# Altium schematic sheet [04] - MCU.SchDoc("[04] - MCU")
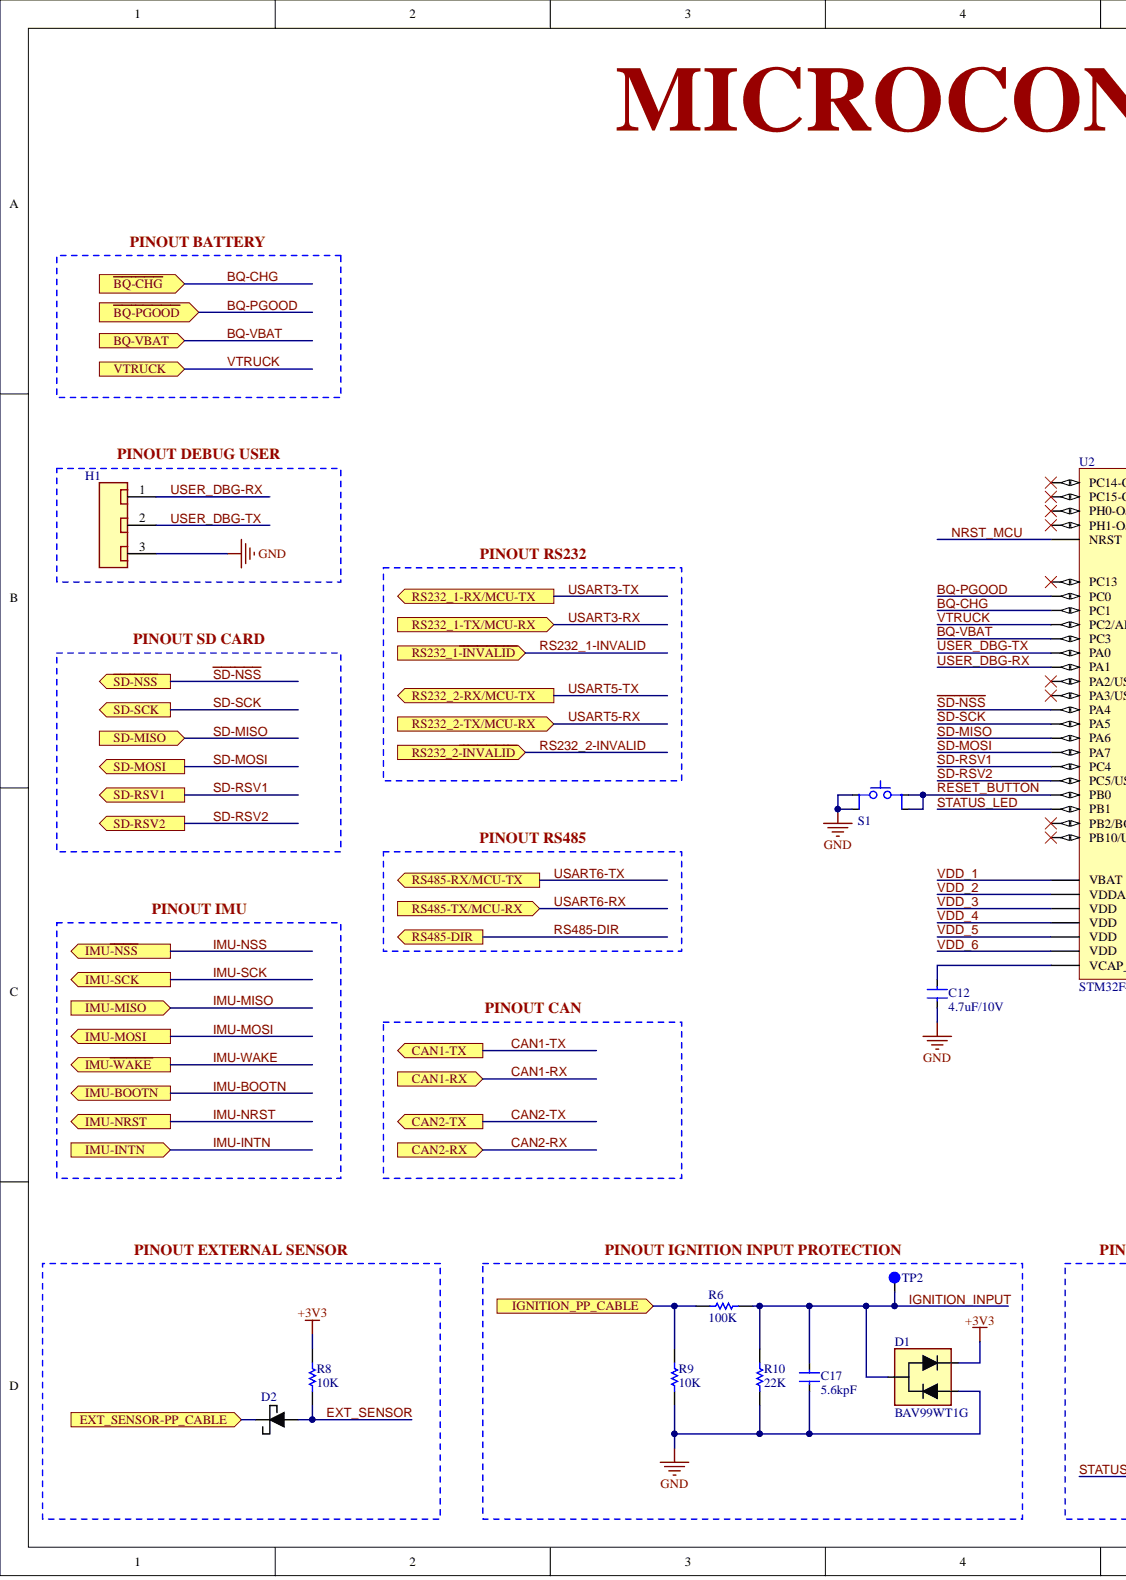
[diagram p0: [04] - MCU.SchDoc, left]
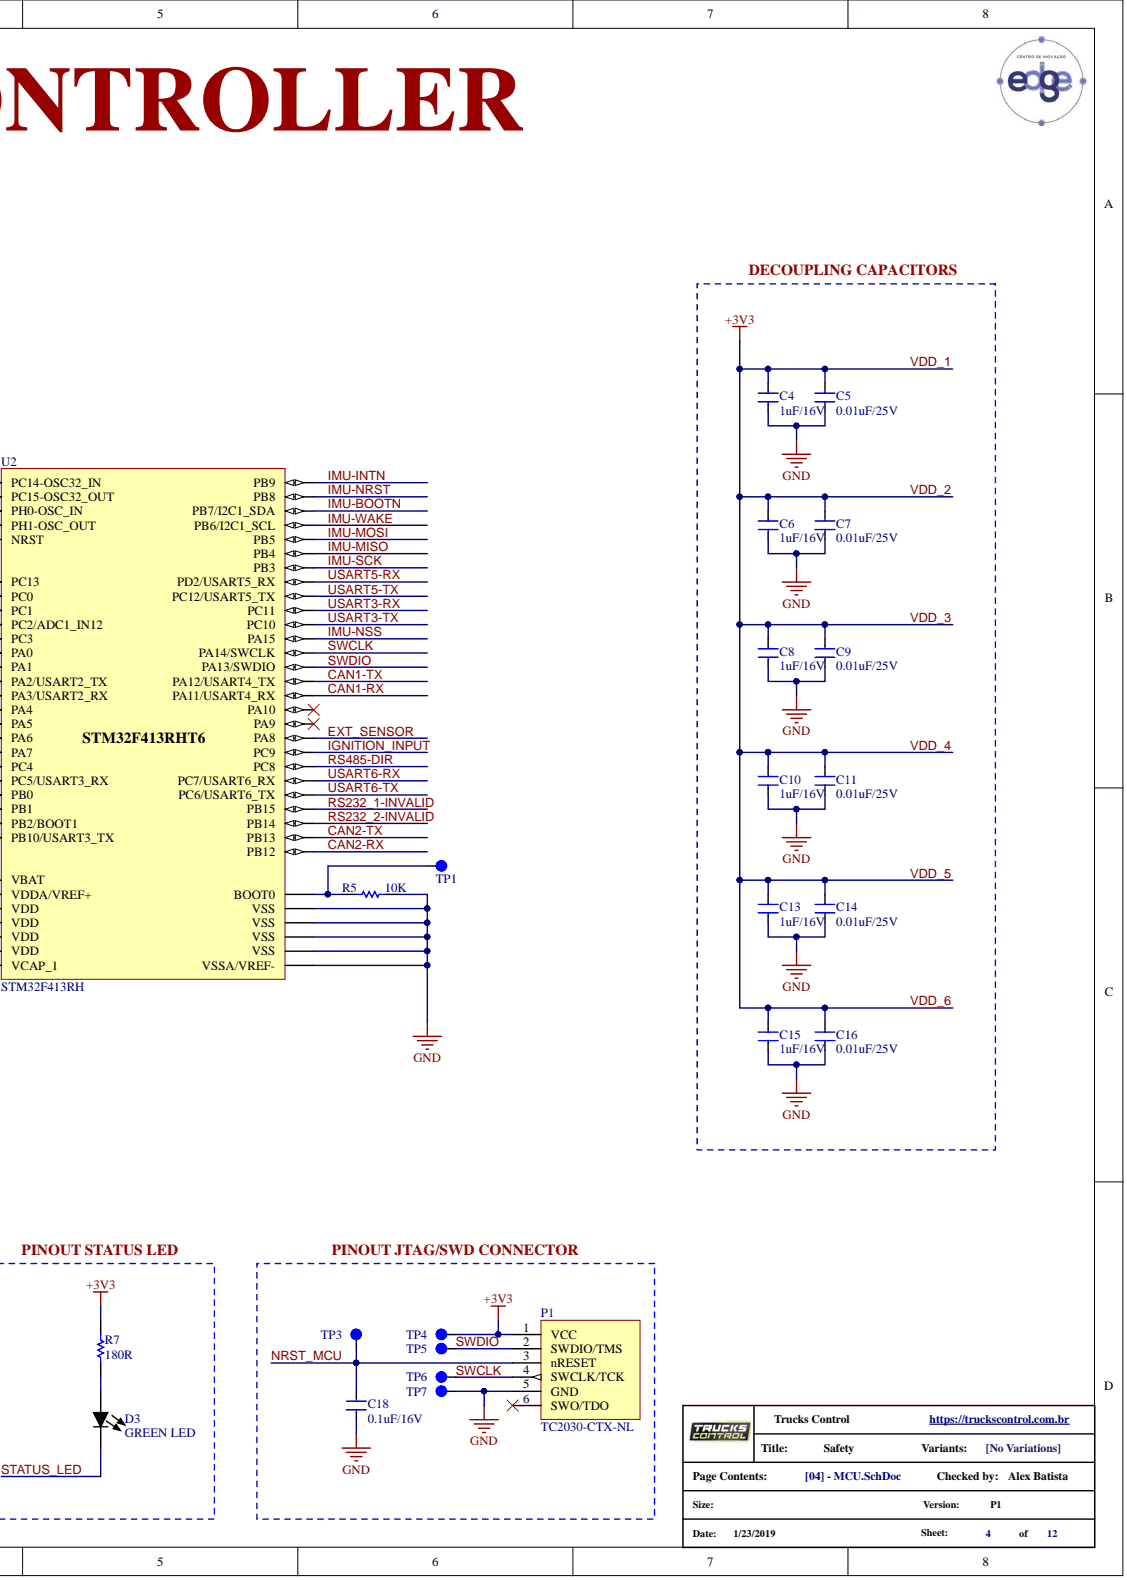
[diagram p1: [04] - MCU.SchDoc, right]

## Parts
C4  1uF/16V  [C0402]
C5  0.01uF/25V  [C0402]
C6  1uF/16V  [C0402]
C7  0.01uF/25V  [C0402]
C8  1uF/16V  [C0402]
C9  0.01uF/25V  [C0402]
C10  1uF/16V  [C0402]
C11  0.01uF/25V  [C0402]
C12  4.7uF/10V  [C0402]
C13  1uF/16V  [C0402]
C14  0.01uF/25V  [C0402]
C15  1uF/16V  [C0402]
C16  0.01uF/25V  [C0402]
C17  5.6kpF  [C0805]
C18  0.1uF/16V  [C0402]
D1  BAV99WT1G  [SOT-323]
D2  CCS15S40,L3F  [CCS15S40,L3F]  (value not drawn)
D3  GREEN LED  [HSMG-C680]
H1  Pin Header 1x3  [HEADER_1x3]  (value not drawn)
P1  TC2030-CTX-NL  [TC2030-CTX-NL]  (no BOM)
R5  10K  [R0402]
R6  100K  [R0805]
R7  180R  [R0402]
R8  10K  [R0402]
R9  10K  [R0805]
R10  22K  [R0805]
S1  Switch Button  [TL1014BF160QG]  (value not drawn)
TP1  TestPoint  [Test Point]  (value not drawn)  (no BOM)
TP2  TestPoint  [Test Point]  (value not drawn)  (no BOM)
TP3  TestPoint  [Test Point]  (value not drawn)  (no BOM)
TP4  TestPoint  [Test Point]  (value not drawn)  (no BOM)
TP5  TestPoint  [Test Point]  (value not drawn)  (no BOM)
TP6  TestPoint  [Test Point]  (value not drawn)  (no BOM)
TP7  TestPoint  [Test Point]  (value not drawn)  (no BOM)
U2  STM32F413RH  [TSQFP50P1200X1200X160-64N]

## Ports
BQ-VBAT, CAN1-RX, CAN1-TX, CAN2-RX, CAN2-TX, EXT_SENSOR-PP_CABLE, IGNITION_PP_CABLE, IMU-BOOTN, IMU-INTN, IMU-MISO, IMU-MOSI, IMU-NRST, IMU-SCK, IMU~{-NSS}, IMU~{-WAKE}, RS232_1-RX/MCU-TX, RS232_1-TX/MCU-RX, RS232_1~{-INVALID}, RS232_2-RX/MCU-TX, RS232_2-TX/MCU-RX, RS232_2~{-INVALID}, RS485-DIR, RS485-RX/MCU-TX, RS485-TX/MCU-RX, SD-MISO, SD-MOSI, SD-RSV1, SD-RSV2, SD-SCK, VTRUCK, ~{BQ-CHG}, ~{BQ-PGOOD}, ~{SD-NSS}

## Nets
GND: C4.1 C5.2 C6.1 C7.2 C8.1 C9.2 C10.1 C11.2 C12.1 C13.1 C14.2 C15.1 C16.2 C17.1 C18.2 D1.1 H1.3 P1.5(GND) R5.1 R9.1 R10.1 S1.1 S1.3 TP7.1 U2.12(VSSA/VREF-) U2.18(VSS) U2.31(VSS) U2.47(VSS) U2.63(VSS)
+3V3: C4.2 C5.1 C6.2 C7.1 C8.2 C9.1 C10.2 C11.1 C13.2 C14.1 C15.2 C16.1 D1.2 P1.1(VCC) R7.2 R8.2 TP4.1 U2.1(VBAT) U2.13(VDDA/VREF+) U2.19(VDD) U2.32(VDD) U2.48(VDD) U2.64(VDD)
~{SD-NSS}: U2.20(PA4) ; port ~{SD-NSS}
BQ-CHG: U2.9(PC1) ; port ~{BQ-CHG}
BQ-PGOOD: U2.8(PC0) ; port ~{BQ-PGOOD}
BQ-VBAT: U2.11(PC3) ; port BQ-VBAT
CAN1-RX: U2.44(PA11/USART4_RX) ; port CAN1-RX
CAN1-TX: U2.45(PA12/USART4_TX) ; port CAN1-TX
CAN2-RX: U2.33(PB12) ; port CAN2-RX
CAN2-TX: U2.34(PB13) ; port CAN2-TX
EXT_SENSOR: D2.2 R8.1 U2.41(PA8)
EXT_SENSOR-PP_CABLE: D2.1 ; port EXT_SENSOR-PP_CABLE
IGNITION_INPUT: C17.2 D1.3 R6.2 R10.2 TP2.1 U2.40(PC9)
IGNITION_PP_CABLE: R6.1 R9.2 ; port IGNITION_PP_CABLE
IMU-BOOTN: U2.59(PB7/I2C1_SDA) ; port IMU-BOOTN
IMU-INTN: U2.62(PB9) ; port IMU-INTN
IMU-MISO: U2.56(PB4) ; port IMU-MISO
IMU-MOSI: U2.57(PB5) ; port IMU-MOSI
IMU-NRST: U2.61(PB8) ; port IMU-NRST
IMU-NSS: U2.50(PA15) ; port IMU~{-NSS}
IMU-SCK: U2.55(PB3) ; port IMU-SCK
IMU-WAKE: U2.58(PB6/I2C1_SCL) ; port IMU~{-WAKE}
NRST_MCU: C18.1 P1.3(nRESET) TP3.1 U2.7(NRST)
RESET_BUTTON: S1.2 S1.4 U2.26(PB0)
RS232_1-INVALID: U2.36(PB15) ; port RS232_1~{-INVALID}
RS232_2-INVALID: U2.35(PB14) ; port RS232_2~{-INVALID}
RS485-DIR: U2.39(PC8) ; port RS485-DIR
SD-MISO: U2.22(PA6) ; port SD-MISO
SD-MOSI: U2.23(PA7) ; port SD-MOSI
SD-RSV1: U2.24(PC4) ; port SD-RSV1
SD-RSV2: U2.25(PC5/USART3_RX) ; port SD-RSV2
SD-SCK: U2.21(PA5) ; port SD-SCK
STATUS_LED: D3.2 U2.27(PB1)
SWCLK: P1.4(SWCLK/TCK) TP6.1 U2.49(PA14/SWCLK)
SWDIO: P1.2(SWDIO/TMS) TP5.1 U2.46(PA13/SWDIO)
USART3-RX: U2.52(PC11) ; port RS232_1-TX/MCU-RX
USART3-TX: U2.51(PC10) ; port RS232_1-RX/MCU-TX
USART5-RX: U2.54(PD2/USART5_RX) ; port RS232_2-TX/MCU-RX
USART5-TX: U2.53(PC12/USART5_TX) ; port RS232_2-RX/MCU-TX
USART6-RX: U2.38(PC7/USART6_RX) ; port RS485-TX/MCU-RX
USART6-TX: U2.37(PC6/USART6_TX) ; port RS485-RX/MCU-TX
USER_DBG-RX: H1.1 U2.15(PA1)
USER_DBG-TX: H1.2 U2.14(PA0)
VTRUCK: U2.10(PC2/ADC1_IN12) ; port VTRUCK
NetC12_2: C12.2 U2.30(VCAP_1)
NetD3_1: D3.1 R7.1
NetR5_2: R5.2 TP1.1 U2.60(BOOT0)

Not connected: P1 6(SWO/TDO); U2 2(PC13) 3(PC14-OSC32_IN) 4(PC15-OSC32_OUT) 5(PH0-OSC_IN) 6(PH1-OSC_OUT) 16(PA2/USART2_TX) 17(PA3/USART2_RX) 28(PB2/BOOT1) 29(PB10/USART3_TX) 42(PA9) 43(PA10)

## Library data of the parts
C4 C6 C8 C10 C13 C15 = CL05A105MO5NNNC: 1µF ±20% 16V X5R 0402 (1005 Metric)Ceramic Capacitor -55°C ~ 85°C Surface Mount, MLCC; Manufacturer: Samsung; Capacitance: 1uF; Width: 0.02inch; Voltage Rating (DC): 16V; Voltage Rating: 16V; Tolerance: 20%; Thickness: 0.022inch; Supplier Part Number 1: 1276-1447-1-ND; Supplier 1: Digi-Key; Stock 1: 100000; Pricing 1: 1=0.1, 10=0.056, 100=0.0248, 500=0.01772, 1000=0.01392, 2500=0.01266, 5000=0.01164 (USD); Packaging: Tape and Reel; Package Quantity: 10000; Mount: Surface Mount; Min Operating Temperature: -55°C; Max Operating Temperature: 85°C; Manufacturer Part Number: CL05A105MO5NNNC; Length: 0.039inch; Component Kind: Standard; Case Code (Metric): 1005; Case Code (Imperial): 0402; Case/Package: 0402; Library Name: tc-pcb-safety_V1I1.SchLib
C5 C7 C9 C11 C14 C16 = GCM155R71E103KA37D: 0.01uF 25Volts X7R 10% Multilayer Ceramic Capacitors MLCC - SMD/SMT; Manufacturer: Murata; Voltage Rating (DC): 25V; Capacitance: 10nF; Width: 0.02inch; Voltage Rating: 25V; Voltage: 25V; Tolerance: 10%; Thickness: 0.022inch; Termination: SMD/SMT; Supplier Part Number 1: 81-GCM155R71E103KA7D; Supplier 1: Mouser; Stock 1: 163600; REACH SVHC: No SVHC; Ratings: AEC-Q200; Radiation Hardening: No; Pricing 1: 1=0.1, 10=0.046, 50=0.046, 100=0.02, 1000=0.011, 10000=0.007 (USD); Packaging: Tape and Reel; Package Quantity: 1; Mount: Surface Mount; Min Operating Temperature: -55°C; Max Operating Temperature: 125°C; Manufacturer Part Number: GCM155R71E103KA37D; Length: 1mm; Lead Free: Lead Free; Height: 0.5mm; Dielectric: X7R; Depth: 0.5mm; Component Kind: Standard; Case Code (Metric): 1005; Case Code (Imperial): 0402; Case/Package: 0402; Library Name: tc-pcb-safety_V1I1.SchLib
C12 = GRM155R61A475MEAAD: 4.7µF 10V Ceramic Capacitor X5R 0402 (1005 Metric); Manufacturer: Murata; Supplier Part Number 1: 81-GRM155R61A475MEAD; Supplier 1: Mouser; Stock 1: 121376; Pricing 1: 1=0.25, 10=0.169, 50=0.169, 100=0.086, 1000=0.052, 10000=0.035 (USD); Manufacturer Part Number: GRM155R61A475MEAAD; Component Kind: Standard; Case/Package: 0402; Library Name: tc-pcb-safety_V1I1.SchLib
C17 = C0805C562M2RACTU: 5600pF  200volts X7R 20%  0805  Multilayer Ceramic Capacitors MLCC - SMD/SMT; Manufacturer: KEMET; Voltage Rating (DC): 200V; Capacitance: 5.6nF; Voltage Rating: 200V; Tolerance: 20%; Termination: SMD/SMT; Supplier Part Number 1: 80-C0805C562M2R; Supplier 1: Mouser; Stock 1: 17999; Pricing 1: 1=0.31, 10=0.161, 50=0.161, 100=0.097, 1000=0.076, 10000=0.054 (USD); Packaging: Tape and Reel; Package Quantity: 4000; Min Operating Temperature: -55°C; Max Operating Temperature: 125°C; Manufacturer Part Number: C0805C562M2RACTU; Dielectric Material: Ceramic; Component Kind: Standard; Case Code (Metric): 2012; Case Code (Imperial): 0805; Case/Package: 0805; Library Name: tc-pcb-safety_V1I1.SchLib
C18 = GCM155R71C104KA55D: 0.1UF 16V X7R 0402 CAP CER; Manufacturer: Murata; Tolerance: 10%; Capacitance: 100nF; Width: 0.02inch; Voltage Rating (DC): 16V; Voltage Rating: 16V; Voltage: 16V; Thickness: 0.022inch; Termination: SMD/SMT; Supplier Part Number 1: 81-GCM155R71C104KA5D; Supplier 1: Mouser; Stock 1: 3750168; REACH SVHC: No SVHC; Ratings: AEC-Q200; Radiation Hardening: No; Pricing 1: 1=0.1, 10=0.061, 50=0.061, 100=0.026, 1000=0.015, 10000=0.01 (USD); Packaging: Tape and Reel; Package Quantity: 1; Mount: Surface Mount; Min Operating Temperature: -55°C; Max Operating Temperature: 125°C; Manufacturer Part Number: GCM155R71C104KA55D; Length: 1mm; Lead Free: Lead Free; Height: 0.5mm; Dielectric: X7R; Depth: 0.5mm; Component Kind: Standard; Case Code (Metric): 1005; Case Code (Imperial): 0402; Case/Package: 0402; Library Name: tc-pcb-safety_V1I1.SchLib
D1 = BAV99WT1G: DIODE ARRAY GP 70V 200MA SOT323; Manufacturer: ON Semiconductor / Fairchild; Reverse Recovery Time: 6ns; Power Dissipation: 300mW; Peak Reverse Current: 2.5uA; Peak Non-Repetitive Surge Current: 2A; Max Reverse Leakage Current: 2.4uA; Forward Voltage: 1V; Element Configuration: Common Cathode; Width: 1.35mm; Weight: 0.03g; Termination: SMD/SMT; Supplier Part Number 1: 863-BAV99WT1G; Supplier 1: Mouser; Stock 1: 22267; Reverse Voltage: 70V; Recovery Time: 6ns; REACH SVHC: No SVHC; Radiation Hardening: No; Pricing 1: 1=0.15, 10=0.13, 50=0.13, 100=0.046, 1000=0.031, 10000=0.021 (USD); Packaging: Tape and Reel; Package Quantity: 1; Number of Pins: 3; Mount: Surface Mount; Min Operating Temperature: -65°C; Max Surge Current: 2A; Max Reverse Voltage (DC): 70V; Max Repetitive Reverse Voltage (Vrrm): 70V; Max Operating Temperature: 150°C; Max Forward Surge Current (Ifsm): 2A; Manufacturer Part Number: BAV99WT1G; Length: 2.2mm; Lead Free: Lead Free; Height: 0.9mm; Forward Current: 200mA; Contact Plating: Matte,Tin; Component Kind: Standard; Case/Package: SOT-323; Average Rectified Current: 200mA; Library Name: tc-pcb-safety_V1I1.SchLib
D2 = CCS15S40,L3F: Diode Schottky Barrier Diode 40V 1.5A 2-Pin CSTC; Manufacturer: Toshiba; Peak Non-Repetitive Surge Current: 5A; Element Configuration: Single; Supplier Part Number 1: 757-CCS15S40L3F; Supplier 1: Mouser; Stock 1: 19012; Pricing 1: 10000=0.07 (USD); Packaging: Tape and Reel; Number of Pins: 2; Mount: Surface Mount; Min Operating Temperature: -55°C; Max Reverse Voltage (DC): 40V; Max Repetitive Reverse Voltage (Vrrm): 40V; Max Operating Temperature: 125°C; Manufacturer Part Number: CCS15S40,L3F; Forward Current: 1.5A; Component Kind: Standard; Case/Package: SMD/SMT; Average Rectified Current: 1.5A; Library Name: tc-pcb-safety_V1I1.SchLib
D3 = HSMG-C680: LED GREEN CLEAR 2SMD R/A; Manufacturer: Broadcom Avago; Viewing Angle: 125°; Test Current: 20mA; Reverse Voltage: 5V; Power Rating: 65mW; Number of LEDs: 1; Luminous Intensity: 13.3mcd; Lens Style: Colorless,Diffused,Dome,Rectangular; Lens Colour: Clear,Colorless; Forward Voltage: 2.2V; Forward Current: 25mA; Dominant Wavelength: 573nm; Width: 1mm; Supplier Part Number 1: 630-HSMG-C680; Supplier 1: Mouser; Stock 1: 52216; Radiation Hardening: No; Pricing 1: 1=0.37, 10=0.24, 50=0.24, 100=0.138, 1000=0.108, 10000=0.084 (USD); Power Dissipation: 65mW; Packaging: Tape and Reel; Package Quantity: 1; Number of Pins: 2; Number of Elements: 1; Mount: Surface Mount; Min Operating Temperature: -40°C; Max Power Dissipation: 65mW; Max Operating Temperature: 85°C; Manufacturer Part Number: HSMG-C680; Lens Transparency: Clear; Length: 3mm; Lead Free: Lead Free; Illumination Colour: Green; Height: 1mm; Depth: 1mm; Contact Plating: Gold; ComponentLink2URL: https://www.verical.com/server-webapp/html/getDatasheet?partID=138939; ComponentLink2Description: https://www.verical.com/server-webapp/html/getDatasheet?partID=138939; ComponentLink1URL: https://www.distrelec.biz/Web/Downloads/_t/ds/HSMx-C680_eng_tds.pdf?mime=application%2Fpdf; ComponentLink1Description: https://www.distrelec.biz/Web/Downloads/_t/ds/HSMx-C680_eng_tds.pdf?mime=application%2Fpdf; Component Kind: Standard; Colour: Green; Case/Package: SMD/SMT; Library Name: tc-pcb-safety_V1I1.SchLib
H1 = 61300311121: WURTH ELEKTRONIK         61300311121            Board-To-Board Connector, Vertical, WR-PHD Series, Through Hole, Header, 3 Contacts, 2.54 mm; Manufacturer: Wurth Electronics; Pitch: 0.1inch; Number of Positions: 3; Gender: Male; Connector Type: Male Pin; Termination: Solder; Supplier Part Number 1: 710-61300311121; Supplier 1: Mouser; Stock 1: 19893; REACH SVHC: Unknown; Pricing 1: 1=0.13, 10=0.106, 50=0.074, 100=0.067, 1000=0.061, 10000=0.061 (USD); Packaging: Bulk; Number of Rows: 1; Number of Contacts: 3; Mount: Through Hole; Manufacturer Part Number: 61300311121; Lead Free: Lead Free; Contact Plating: Gold; Contact Mating Length: 0.236inch; Contact Material: Copper; Component Kind: Standard; Colour: Black; Library Name: tc-pcb-safety_V1I1.SchLib
P1 = TC2030-CTX-NL: 6 pin Tag-Connect; Library Name: tc-pcb-safety_V1I1.SchLib
R5 R8 = RC0402JR-7D10KL: 10K OHM 5% 1/16W 0402; Manufacturer: Yageo; Supplier Part Number 1: 603-RC0402JR-7D10KL; Supplier 1: Mouser; Stock 1: 178452; Pricing 1: 1=0.1, 10=0.01, 50=0.01, 100=0.004, 1000=0.003, 10000=0.002 (USD); Manufacturer Part Number: RC0402JR-7D10KL; Component Kind: Standard; Library Name: tc-pcb-safety_V1I1.SchLib
R6 = ERJ-6ENF1003V: 100K Ohm 1%  150V 0.125W(1/8W) 0805 Res Thick Film  ±100ppm/C Molded SMD Automotive Punched T/R; Manufacturer: Panasonic; Temperature Coefficient: 100ppm/°C; Resistance: 100kR; Power Rating: 125mW; Width: 0.049inch; Voltage Rating (DC): 150V; Voltage Rating: 150V; Tolerance: 1%; Termination: SMD/SMT; Supplier Part Number 1: 667-ERJ-6ENF1003V; Supplier 1: Mouser; Stock 1: 383457; REACH SVHC: No SVHC; Radiation Hardening: No; Pricing 1: 1=0.1, 10=0.046, 50=0.046, 100=0.026, 1000=0.015, 10000=0.01 (USD); Packaging: Tape and Reel; Package Quantity: 1; Number of Terminations: 2; Number of Pins: 2; Mount: Surface Mount; Min Operating Temperature: -55°C; Military Standard: Not; Max Power Dissipation: 125mW; Max Operating Temperature: 155°C; Manufacturer Part Number: ERJ-6ENF1003V; Length: 2mm; Lead Free: Lead Free; Height: 0.6mm; Features: Automotive AEC-Q200; Depth: 1.25mm; Contact Plating: Tin; Composition: Thick Film; Component Kind: Standard; Case Code (Metric): 2012; Case Code (Imperial): 0805; Case/Package: 0805; Library Name: tc-pcb-safety_V1I1.SchLib
R7 = ERJ-2GEJ181X: 180 ohm, 50 V, 5%, 0402 [1005 Metric], 100 mW, ERJ2G Series; Manufacturer: Panasonic; Voltage Rating (DC): 50V; Temperature Coefficient: 200ppm/°C; Power Rating: 100mW; Width: 0.02inch; Voltage Rating: 50V; Tolerance: 5%; Termination: SMD/SMT; Supplier Part Number 1: 667-ERJ-2GEJ181X; Supplier 1: Mouser; Stock 1: 24191; Resistance: 180R; REACH SVHC: No SVHC; Radiation Hardening: No; Pricing 1: 1=0.13, 10=0.018, 50=0.018, 100=0.01, 1000=0.006, 10000=0.004 (USD); Packaging: Tape and Reel; Package Quantity: 1; Number of Terminations: 2; Mount: Surface Mount; Min Operating Temperature: -55°C; Military Standard: Not; Max Power Dissipation: 100mW; Max Operating Temperature: 155°C; Manufacturer Part Number: ERJ-2GEJ181X; Length: 1mm; Lead Free: Lead Free; Height: 0.35mm; Features: Automotive AEC-Q200; Depth: 0.5mm; Contact Plating: Tin; Composition: Thick Film; Component Kind: Standard; Case Code (Metric): 1005; Case Code (Imperial): 0402; Case/Package: 0402; Library Name: tc-pcb-safety_V1I1.SchLib
R9 = ERJ-6ENF1002V: 10K Ohm  150V 0.125W(1/8W)  1%  0805 Res Thick Film ±100ppm/C Molded SMD Automotive Punched T/R; Manufacturer: Panasonic; Temperature Coefficient: 100ppm/°C; Resistance: 10kR; Power Rating: 125mW; Working Voltage: 150V; Width: 0.049inch; Voltage Rating (DC): 150V; Voltage Rating: 150V; Tolerance: 1%; Termination: SMD/SMT; Supplier Part Number 1: 667-ERJ-6ENF1002V; Supplier 1: Mouser; Stock 1: 1453745; REACH SVHC: No SVHC; Radiation Hardening: No; Pricing 1: 1=0.1, 10=0.046, 50=0.046, 100=0.026, 1000=0.015, 10000=0.01 (USD); Packaging: Tape and Reel; Package Quantity: 1; Number of Terminations: 2; Number of Pins: 2; Mount: Surface Mount; Min Operating Temperature: -55°C; Military Standard: Not; Max Power Dissipation: 125mW; Max Operating Temperature: 155°C; Manufacturer Part Number: ERJ-6ENF1002V; Length: 2mm; Lead Free: Lead Free; Height: 0.6mm; Features: Automotive AEC-Q200; Depth: 1.25mm; Contact Plating: Tin; Composition: Thick Film; Component Kind: Standard; Case Code (Metric): 2012; Case Code (Imperial): 0805; Case/Package: 0805; Library Name: tc-pcb-safety_V1I1.SchLib
R10 = ERJ-6ENF2202V: 22 kohm, 150 V, 125 mW,  1% 0805 [2012 Metric],  SMD Chip Resistor, Thick Film; Manufacturer: Panasonic; Voltage Rating (DC): 150V; Temperature Coefficient: 100ppm/°C; Resistance: 22kR; Power Rating: 125mW; Width: 1.2mm; Voltage Rating: 150V; Tolerance: 1%; Termination: SMD/SMT; Supplier Part Number 1: 667-ERJ-6ENF2202V; Supplier 1: Mouser; Stock 1: 34949; REACH SVHC: No SVHC; Radiation Hardening: No; Pricing 1: 1=0.1, 10=0.046, 50=0.046, 100=0.026, 1000=0.015, 10000=0.01 (USD); Packaging: Tape and Reel; Package Quantity: 1; Number of Terminations: 2; Number of Pins: 2; Mount: Surface Mount; Min Operating Temperature: -55°C; Military Standard: Not; Max Power Dissipation: 125mW; Max Operating Temperature: 155°C; Manufacturer Part Number: ERJ-6ENF2202V; Length: 2mm; Lead Free: Lead Free; Height: 0.6mm; Features: Automotive AEC-Q200; Depth: 1.25mm; Contact Plating: Tin; Composition: Thick Film; Component Kind: Standard; Case Code (Metric): 2012; Case Code (Imperial): 0805; Case/Package: 0805; Library Name: tc-pcb-safety_V1I1.SchLib
S1 = TL1014BF160QG: SWITCH TACTILE SPST-NO 0.05A 12V; Manufacturer: E-Switch; Throw Configuration: SPST; Switch Travel: 0.3mm; Switch Function: Off-Mom; Operating Force: 160gf; Mechanical Life: 100000Cycles; Illumination Type: Illuminated,Non-Illuminated; Contact Resistance: 100mR; Actuator Material: Nylon,Polyamide; Actuator Length: 1mm; Termination: Gull Wing; Supplier Part Number 1: 612-TL1014BF160QG; Supplier 1: Mouser; Stock 1: 14400; REACH SVHC: No SVHC; Pricing 1: 1=0.84, 10=0.809, 50=0.672, 100=0.61, 1000=0.443, 10000=0.412 (USD); Packaging: Tape and Reel; Package Quantity: 3300; Orientation: Right Angle; Mount: Surface Mount; Min Operating Temperature: -20°C; Max Voltage Rating (DC): 12V; Max Operating Temperature: 70°C; Manufacturer Part Number: TL1014BF160QG; Lead Free: Lead Free; Housing Material: Nylon; Electrical Life: 100000Cycles; ComponentLink1URL: http://spec_sheets.e-switch.com/specs/P410002.pdf; ComponentLink1Description: http://spec_sheets.e-switch.com/specs/P410002.pdf; Component Kind: Standard; Case/Package: SMD/SMT; Library Name: tc-pcb-safety_V1I1.SchLib
TP1-TP7 = Test_Point
  TP1: Library Name: tc-pcb-tracker.SchLib
  TP2-TP7: Library Name: tc-pcb-safety_V1I1.SchLib
U2 = STM32F413RHT6: Manufacturer: STMicroelectronics; Supplier Part Number 1: 511-STM32F413RHT6; Supplier 1: Mouser; Stock 1: 1788; Pricing 1: 1=10.79, 10=9.75, 50=9.3, 100=8.07, 1000=7.03, 10000=7.03 (USD); Manufacturer Part Number: STM32F413RHT6; Library Name: tc-pcb-tracker.SchLib; Component Kind: Standard
